# Altium schematic sheet 3A04xx_Oxocard_Mini_Science_DISP.SchDoc
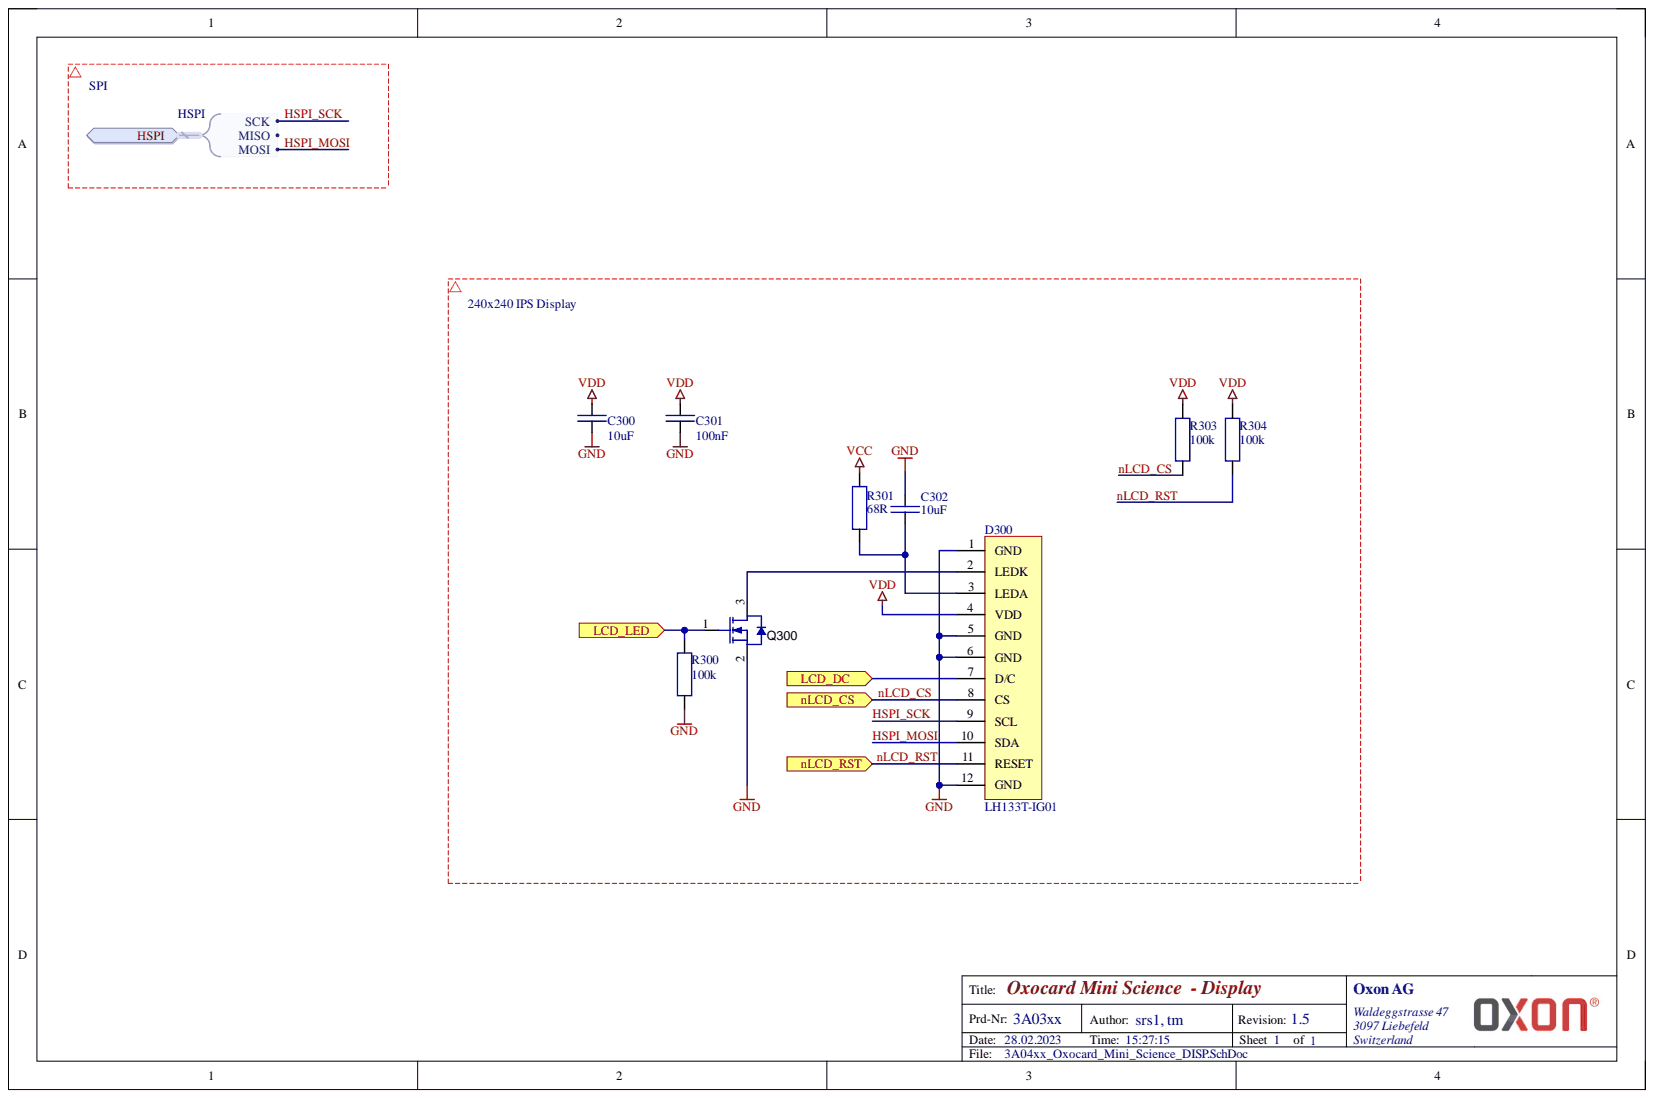
[diagram p0: 3A04xx_Oxocard_Mini_Science_DISP.SchDoc]

## Parts
C300  10uF  [CAPC1005X60]
C301  100nF  [CAPC1005X60]
C302  10uF  [CAPC1005X60]
D300  LH133T-IG01  [X_LH133T-IG01]
Q300  Q-N_MOSFET_1Channel_20V  [SOT-323]  (value not drawn)
R300  100k  [RESC1005X40]
R301  68R  [RESC1005X40]
R303  100k  [RESC1005X40]
R304  100k  [RESC1005X40]

## Ports
HSPI, HSPI.MISO, HSPI.MOSI, HSPI.SCK, LCD_DC, LCD_LED, nLCD_CS, nLCD_RST

## Nets
GND: C300.1 C301.1 C302.2 D300.1(GND) D300.5(GND) D300.6(GND) D300.12(GND) Q300.2 R300.2
VCC: R301.2
VDD: C300.2 C301.2 D300.4(VDD) R303.2 R304.2
HSPI_MOSI: D300.10(SDA) ; port HSPI
HSPI_SCK: D300.9(SCL) ; port HSPI
LCD_DC: D300.7(D/C) ; port LCD_DC
LCD_LED: Q300.1 R300.1 ; port LCD_LED
nLCD_CS: D300.8(CS) R303.1 ; port nLCD_CS
nLCD_RST: D300.11(RESET) R304.1 ; port nLCD_RST
NetC302_1: C302.1 D300.3(LEDA) R301.1
NetD300_2: D300.2(LEDK) Q300.3
N00001: ; port HSPI

## Library data of the parts
C300 C302 = C-CAP0402_10u: SMD capacitor 6.3V 20%; Manufacturer: Wurth Electronics; Manufacturer Designator: ZRB15XR60J106ME2D; Component Kind: Standard; Distributor: Mouser; Distributor Part-Number: 81-ZRB15XR60J106ME2D; Library Name: XLib.SCHLIB
C301 = C-CAP0402_100n: SMD capacitor 10V 5%; Manufacturer: Wurth Electronics; Manufacturer Designator: GRM155R61A104JA1D; Component Kind: Standard; Distributor: Mouser; Distributor Part-Number: 81-GRM155R61A104JA1D; Library Name: XLib.SCHLIB
D300 = D-LH133T-IG01: 240x240 IPS Display; Manufacturer: Shineworld Innovation Limited; Component Kind: Standard; Distributor: Alibaba; Library Name: XLib.SCHLIB; Manufacturer Designator: LH133T-IG01
Q300 = Q-N_MOSFET_1Channel_20V: MOSFET, N-CH,20V, 3.5A; Manufacturer: Diodes Incorporated; Manufacturer Designator: DMN2058UW-7; Component Kind: Standard; Distributor: Mouser; Distributor Part-Number: 621-DMN2058UW-7; Library Name: XLib.SCHLIB
R300 R303 R304 = R-RES0402_100k: SMD resistor 1%; Manufacturer: Yageo; Manufacturer Designator: RC0402FR-07100KL; Component Kind: Standard; Distributor: Mouser; Distributor Part-Number: 603-RC0402FR-07100KL; Library Name: XLib.SCHLIB
R301 = R-RES0402_68R: SMD resistor 1%; Manufacturer: Yageo; Manufacturer Designator: RT0402FRE0768RL; Component Kind: Standard; Distributor: Mouser; Distributor Part-Number: 603-RT0402FRE0768RL; Library Name: XLib.SCHLIB
